# Altium schematic sheet Mppt.SchDoc(Mppt)
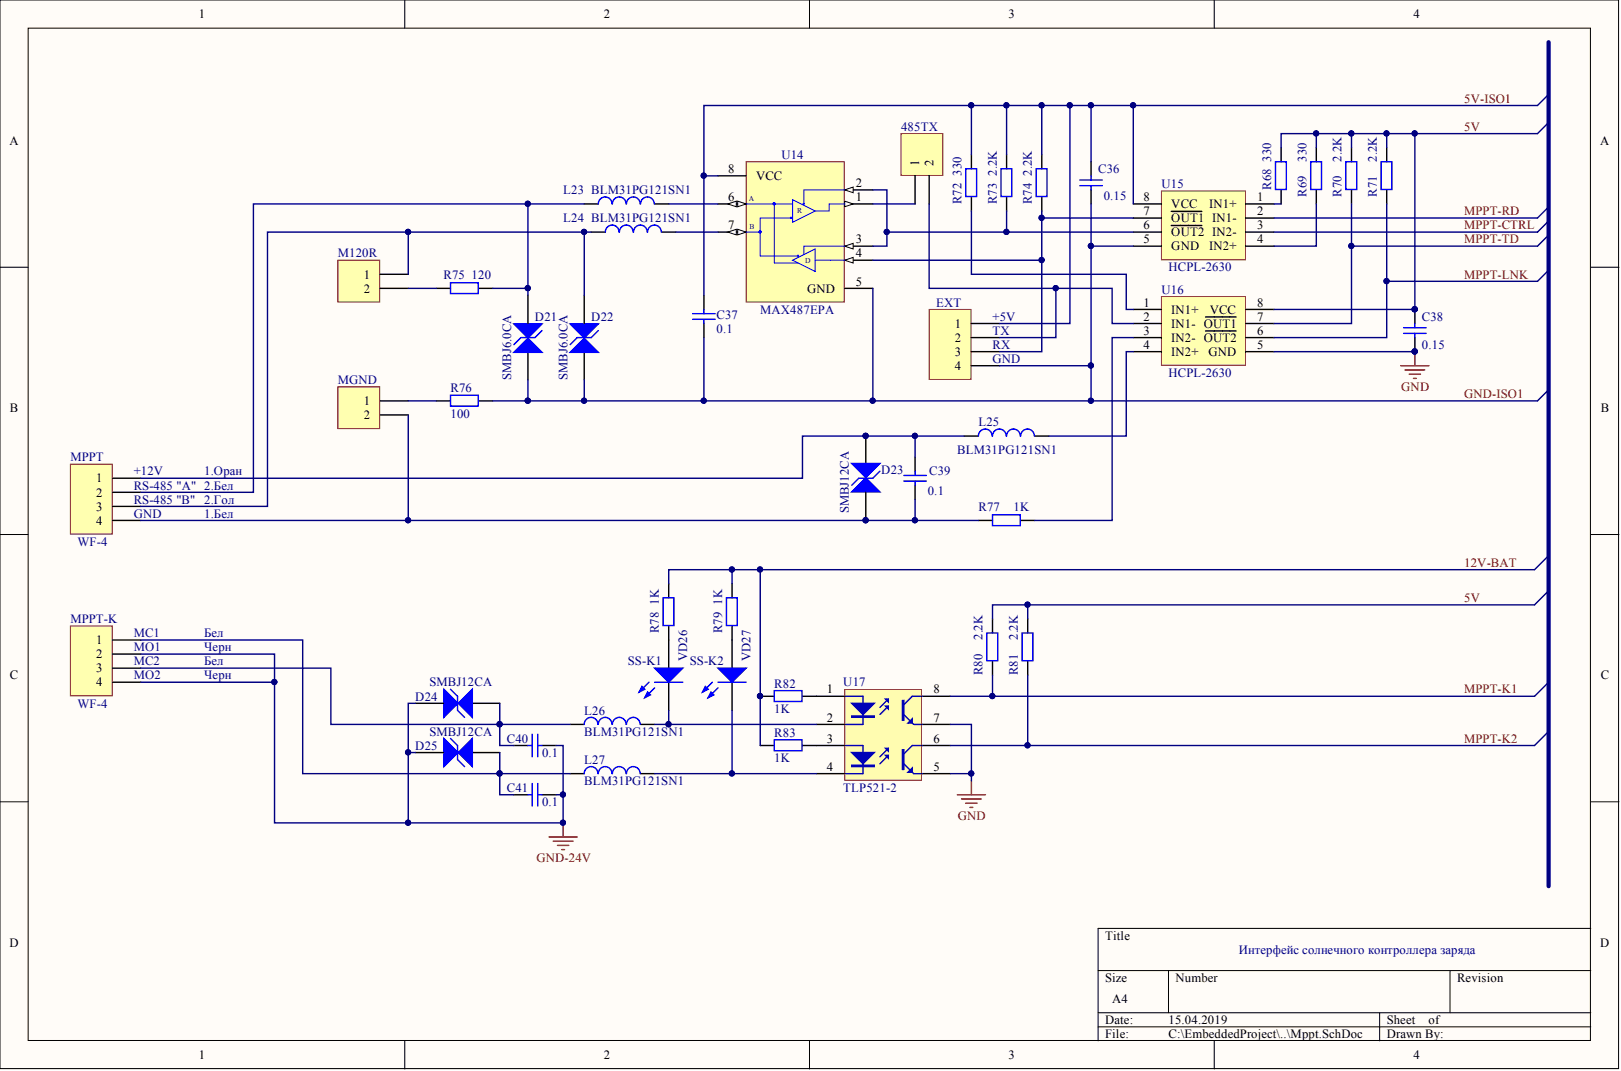
[diagram p0: Mppt.SchDoc]

## Parts
485TX  (no value)  [HDR1X2]
C36  value 0.15  [C1206]  (hidden comment: Cap)
C37  value 0.1  [C1206]  (hidden comment: Cap)
C38  value 0.15  [C1206]  (hidden comment: Cap)
C39  value 0.1  [C1206]  (hidden comment: Cap)
C40  value 0.1  [C1206]  (hidden comment: Cap)
C41  value 0.1  [C1206]  (hidden comment: Cap)
D21  SMBJ6.0CA  [DO-214aa]
D22  SMBJ6.0CA  [DO-214aa]
D23  SMBJ12CA  [DO-214AA]
D24  SMBJ12CA  [DO-214AA]
D25  SMBJ12CA  [DO-214AA]
EXT  Header 4  [HDR1X4]  (value not drawn)
L23  value BLM31PG121SN1  [L1206]  (hidden comment: Ferrite Chip Beads)
L24  value BLM31PG121SN1  [L1206]  (hidden comment: Ferrite Chip Beads)
L25  value BLM31PG121SN1  [L1206]  (hidden comment: Ferrite Chip Beads)
L26  value BLM31PG121SN1  [L1206]  (hidden comment: Ferrite Chip Beads)
L27  value BLM31PG121SN1  [L1206]  (hidden comment: Ferrite Chip Beads)
M120R  JP2  [HDR1X2]  (value not drawn)
MGND  JP2  [HDR1X2]  (value not drawn)
MPPT  value WF-4  [WF-4]  (hidden comment: Header, 4-Pin)
MPPT-K  value WF-4  [WF-4]  (hidden comment: Header, 4-Pin)
R68  value 330  [1206]  (hidden comment: Res2)
R69  value 330  [1206]  (hidden comment: Res2)
R70  value 2.2K  [1206]  (hidden comment: Res2)
R71  value 2.2K  [1206]  (hidden comment: Res2)
R72  value 330  [1206]  (hidden comment: Res2)
R73  value 2.2K  [1206]  (hidden comment: Res2)
R74  value 2.2K  [1206]  (hidden comment: Res2)
R75  value 120  [1206]  (hidden comment: Res2)
R76  value 100  [1206]  (hidden comment: Res2)
R77  value 1K  [1206]  (hidden comment: Res2)
R78  value 1K  [1206]  (hidden comment: Res2)
R79  value 1K  [1206]  (hidden comment: Res2)
R80  value 2.2K  [1206]  (hidden comment: Res2)
R81  value 2.2K  [1206]  (hidden comment: Res2)
R82  value 1K  [1206]  (hidden comment: Res2)
R83  value 1K  [1206]  (hidden comment: Res2)
U14  value MAX487EPA  [DIP-8]  (hidden comment: Low-Power, Slew-Rate-Limited RS-485/RS-422 Transceiver)
U15  HCPL-2630  [DIP-8]
U16  HCPL-2630  [DIP-8]
U17  TLP521-2  [DIP-8 - OPTO]
VD26  SS-K1  [LED1206 - YELOW]
VD27  SS-K2  [LED1206 - YELOW]

## Nets
GND: C38.2 U16.5(GND) U17.5 U17.7
GND-24V: C40.2 C41.2 D24.2 D25.2 MPPT-K.2 MPPT-K.4
5V: C38.1 R68.1 R69.1 R70.1 R71.1 R80.1 R81.1 U16.8(VCC)
5V-ISO1: C36.1 C37.1 EXT.1 R72.1 R73.1 R74.1 U14.8(VCC) U15.8(VCC)
12V-BAT: R78.2 R79.2 R82.1 R83.1
GND-ISO1: C36.2 C37.2 D21.1 D22.1 EXT.4 R76.1 U14.5(GND) U15.5(GND)
MPPT-CTRL: U15.3(IN2-)
MPPT-K1: R80.2 U17.8
MPPT-K2: R81.2 U17.6
MPPT-LNK: R71.2 U16.6(OUT2)
MPPT-RD: U15.2(IN1-)
MPPT-TD: R70.2 U16.7(OUT1)
Net485TX_1: 485TX.1 U14.1
Net485TX_2: 485TX.2 EXT.2 U16.2(IN1-)
NetC39_1: C39.1 D23.1 L25.1 MPPT.1
NetC39_2: C39.2 D23.2 MGND.2 MPPT.4 R77.1
NetC40_1: C40.1 D24.1 L26.1 MPPT-K.3
NetC41_1: C41.1 D25.1 L27.1 MPPT-K.1
NetD21_2: D21.2 L23.1 MPPT.2 R75.2
NetD22_2: D22.2 L24.1 M120R.1 MPPT.3
NetEXT_3: EXT.3 R74.2 U14.4 U15.7(OUT1)
NetL23_2: L23.2 U14.6
NetL24_2: L24.2 U14.7
NetL25_2: L25.2 U16.4(IN2+)
NetL26_2: L26.2 U17.2 VD26.2
NetL27_2: L27.2 U17.4 VD27.2
NetM120R_2: M120R.2 R75.1
NetMGND_1: MGND.1 R76.2
NetR68_2: R68.2 U15.1(IN1+)
NetR69_2: R69.2 U15.4(IN2+)
NetR72_2: R72.2 U16.1(IN1+)
NetR73_2: R73.2 U14.2 U14.3 U15.6(OUT2)
NetR77_2: R77.2 U16.3(IN2-)
NetR78_1: R78.1 VD26.1
NetR79_1: R79.1 VD27.1
NetR82_2: R82.2 U17.1
NetR83_2: R83.2 U17.3
N00458:

## Library data of the parts
485TX M120R MGND = Header 2: Header, 2-Pin; value: Jumper; Component Kind: Standard; LatestRevisionDate: 17-Jul-2002; LatestRevisionNote: Re-released for DXP Platform.; Library Name: Miscellaneous Connectors.IntLib; Signal Integrity: Connector; Publisher: Altium Limited
C36-C41 = Cap: Capacitor; Component Kind: Standard; LatestRevisionDate: 17-Jul-2002; LatestRevisionNote: Re-released for DXP Platform.; Library Name: Miscellaneous Devices.IntLib; PackageReference: RAD-0.3; Published: 8-Jun-2000; Signal Integrity: Cap; Simulation: CAP; Publisher: Altium Limited
D21 D22 = SMBJ6.0CA: Voltage suppressor; Component Kind: Standard; Library Name: Schlib1.SchLib; Value: SMBJ6.0CA
D23-D25 = SMBJ12CA: Voltage suppressor; Component Kind: Standard; Library Name: Schlib1.SchLib; Value: SMBJ12CA
EXT = Header 4: Header, 4-Pin; Component Kind: Standard; LatestRevisionDate: 17-Jul-2002; LatestRevisionNote: Re-released for DXP Platform.; Library Name: Miscellaneous Connectors.IntLib; Signal Integrity: Connector; value: Header 4; Publisher: Altium Limited
L23-L27 = Inductor: Code_IEC: 1005; Code_JEITA: 0402; Component Kind: Standard; Description: Ferrite Chip Beads; LatestRevisionDate: 29-May-2009; LatestRevisionNote: IPC-7351 Footprint Added.; Library Name: Miscellaneous Devices.IntLib; PackageDescription: Chip Inductor; PackageReference: 0402-A; Published: 8-Jun-2000; Signal Integrity: Inductor; Simulation: INDUCTOR; Publisher: Altium Limited
MPPT MPPT-K = Header 4: Component Kind: Standard; Description: Header, 4-Pin; LatestRevisionDate: 17-Jul-2002; LatestRevisionNote: Re-released for DXP Platform.; Library Name: Miscellaneous Connectors.IntLib; Signal Integrity: Connector; Publisher: Altium Limited
R68-R83 = Res2: Resistor; Component Kind: Standard; LatestRevisionDate: 17-Jul-2002; LatestRevisionNote: Re-released for DXP Platform.; Library Name: Miscellaneous Devices.IntLib; PackageReference: AXIAL-0.4; Published: 8-Jun-2000; Signal Integrity: Res; Simulation: RESISTOR; Publisher: Altium Limited
U14 = MAX487CPA: Code_JEDEC: MS-001-AB; Component Kind: Standard; ComponentLink1Description: Manufacturer Link; ComponentLink1URL: http://www.maxim-ic.com/; ComponentLink2Description: Datasheet; ComponentLink2URL: http://pdfserv.maxim-ic.com/en/ds/MAX1487-MAX491.pdf; DatasheetVersion: Feb-1996; Description: Low-Power, Slew-Rate-Limited RS-485/RS-422 Transceiver; LatestRevisionDate: 29-May-2009; LatestRevisionNote: Component Body Added.; Library Name: Maxim Communication Transceiver.IntLib; PackageDescription: DIP, 8-Pin, Row Spacing 7.62 mm, Pitch 2.54 mm; PackageReference: PA8; PackageVersion: RevB, 21-0043; PCB3D: PA8; Published: 16-Jan-2001; Publisher: Altium Limited
U15 U16 = HCPL-2630: High speed-10 mbit/s logic gate optocouplers dual-channel; Component Kind: Standard; Library Name: Schlib1.SchLib; Value: HCPL-2630
U17 = TLP521-2: 8-Pin Phototransistor Optocoupler; Component Kind: Standard; DatasheetVersion: Nov-2003; LatestRevisionDate: 04-Mar-2005; LatestRevisionNote: Sch pins 3 & 4 Swapped.; Library Name: Schlib1.SchLib; PackageReference: DIP-4; Published: 24-Mar-1999; Value: TLP521-2; Publisher: Altium Limited
VD26 VD27 = LED0: SMD LED; value: ORANGE; Component Kind: Standard; LatestRevisionDate: 08-Jul-2005; LatestRevisionNote: Mech Layer 1 removed.; Library Name: Miscellaneous Devices.IntLib; PackageReference: LED-0; Published: 8-Jun-2000; Simulation: LED0; Publisher: Altium Limited
